# Altium schematic sheet Digital.SchDoc
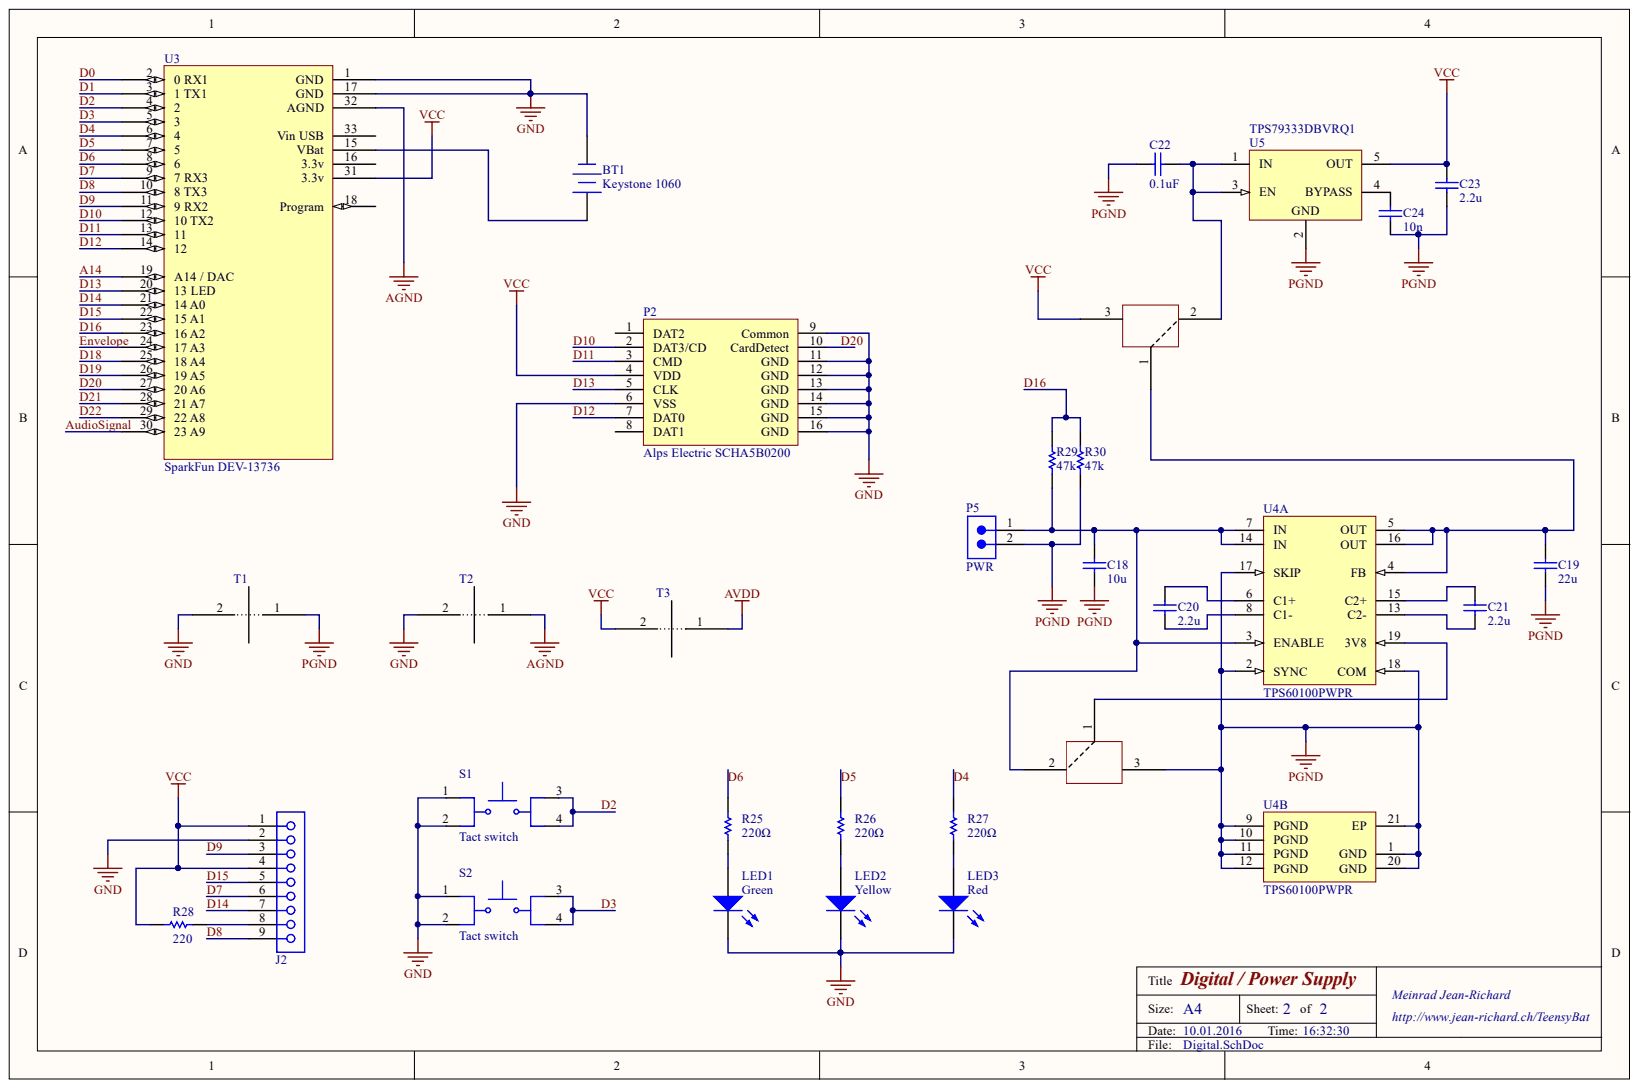
[diagram p0: Digital.SchDoc]

## Parts
BT1  Keystone 1060  [PCB-785wwjdgfrelf4kzosmk-1]
C18  10u  [CAPC3216X178X50ML20T25]
C19  22u  [CAPC3225X280X50ML20T25]
C20  2.2u  [CAPC3216X178X50LL20T25]
C21  2.2u  [CAPC3216X178X50LL20T25]
C22  Capacitance: 0.1uF  [CAPC3216X94X50NL20T25]  (hidden comment: AVX Interconnect / Elco 12065C104KAT2A)
C23  2.2u  [CAPC3216X178X50LL20T25]
C24  10n  [CAPC3216X94X50ML20T25]
J2  Harwin M20-7820942  [PCB-l9sv7n8riqbj6ti1phnw-1]  (value not drawn)
J5  Solder Jumper  [MJR-Jumper]  (value not drawn)
J6  Solder Jumper  [MJR-Jumper]  (value not drawn)
LED1  Color: Green  [Type 5mm]  (hidden comment: 151051VS04000)
LED2  Color: Yellow  [Type 5mm]  (hidden comment: 151051YS04000)
LED3  Color: Red  [Type 5mm]  (hidden comment: 151051RS11000)
P2  Alps Electric SCHA5B0200  Manufacturer Part Number: SCHA5B0200  [SD-MICRO-SCHA5B0200]
P5  PWR  [HDR102]
R25  Resistance: 220Ω  [RESC3216X70X50NL05T20]  (hidden comment: Panasonic ERJ-8ENF2200V)
R26  Resistance: 220Ω  [RESC3216X70X50NL05T20]  (hidden comment: Panasonic ERJ-8ENF2200V)
R27  Resistance: 220Ω  [RESC3216X70X50NL05T20]  (hidden comment: Panasonic ERJ-8ENF2200V)
R28  Panasonic ERJ-8ENF2200V  [RESC3216X70X50NL05T20]  (value not drawn)
R29  47k  [RESC3216X70X50NL05T20]
R30  47k  [RESC3216X70X50NL05T20]
S1  Tact switch  [PCB-1275082-1]
S2  Tact switch  [PCB-1275082-1]
T1  Generic Net Tie  [PCB-NetTie]  (value not drawn)
T2  Generic Net Tie  [PCB-NetTie]  (value not drawn)
T3  Generic Net Tie  [PCB-NetTie]  (value not drawn)
U3  SparkFun DEV-13736  Manufacturer Part Number: DEV-13736  [Teensy-3_2-Pins]
U4  TPS60100PWPR  [TI-PWP20-2680X1950TP_M]  (parts A,B)
U5  TPS79333DBVRQ1  [TI-DBV5_N]

## Nets
AGND: T2.1 U3.32(AGND)
AVDD: T3.1
GND: BT1.2 J2.2 LED1.1 LED2.1 LED3.1 P2.6(VSS) P2.9(Common) P2.11(GND) P2.12(GND) P2.13(GND) P2.14(GND) P2.15(GND) P2.16(GND) S1.1 S1.2 S2.1 S2.2 T1.2 T2.2 U3.1(GND) U3.17(GND)
PGND: C18.1 C19.1 C22.1 C23.1 C24.1 J6.3 P5.2 R30.1 T1.1 U4.1(GND) U4.2(SYNC) U4.9(PGND) U4.10(PGND) U4.11(PGND) U4.12(PGND) U4.17(SKIP) U4.18(COM) U4.20(GND) U4.21(EP) U5.2
VCC: C23.2 J2.1 J2.4 J5.3 P2.4(VDD) R28.1 T3.2 U3.31(3.3v) U5.5(OUT)
A14: U3.19(A14_/_DAC)
AUDIOSIGNAL: U3.30(23_A9)
D0: U3.2(0_RX1)
D1: U3.3(1_TX1)
D2: S1.3 S1.4 U3.4
D3: S2.3 S2.4 U3.5
D4: R27.2 U3.6
D5: R26.2 U3.7
D6: R25.2 U3.8
D7: J2.6 U3.9(7_RX3)
D8: J2.9 U3.10(8_TX3)
D9: J2.3 U3.11(9_RX2)
D10: P2.2(DAT3/CD) U3.12(10_TX2)
D11: P2.3(CMD) U3.13
D12: P2.7(DAT0) U3.14
D13: P2.5(CLK) U3.20(13_LED)
D14: J2.7 U3.21(14_A0)
D15: J2.5 U3.22(15_A1)
D16: R29.2 R30.2 U3.23(16_A2)
D18: U3.25(18_A4)
D19: U3.26(19_A5)
D20: P2.10(CardDetect) U3.27(20_A6)
D21: U3.28(21_A7)
D22: U3.29(22_A8)
ENVELOPE: U3.24(17_A3)
NetBT1_1: BT1.1 U3.15(VBat)
NetC18_2: C18.2 J6.2 P5.1 R29.1 U4.3(ENABLE) U4.7(IN) U4.14(IN)
NetC19_2: C19.2 J5.1 U4.4(FB) U4.5(OUT) U4.16(OUT)
NetC20_1: C20.1 U4.8(C1-)
NetC20_2: C20.2 U4.6(C1+)
NetC21_1: C21.1 U4.13(C2-)
NetC21_2: C21.2 U4.15(C2+)
NetC22_2: C22.2 J5.2 U5.1(IN) U5.3(EN)
NetC24_2: C24.2 U5.4(BYPASS)
NetJ2_8: J2.8 R28.2
NetJ6_1: J6.1 U4.19(3V8)
NetLED1_2: LED1.2 R25.1
NetLED2_2: LED2.2 R26.1
NetLED3_2: LED3.2 R27.1

Not connected: P2 1(DAT2) 8(DAT1); U3 16(3.3v) 18(Program) 33(Vin_USB)

## Library data of the parts
BT1 = CMP-19301741-5: Coin Cell Battery Holders 20MM (2032) SMT CELL HOLDER; Manufacturer Part Number: 1060; Lead-Free Status: Lead Free; Number of Contacts: 2; Color: Black; Component Kind: Standard; Contact Material: Phosphor Bronze; Library Name: CommunityVault; Material: Nylon; Mounting Style: Surface Mount; OctopartID: 24a1eda95ca6fb66; Octopart URL: http://octopart.com/1060-keystone-154977; Packaging: Tray; REACH SVHC Compliance: No SVHC; RoHS: Compliant; Size-Diameter: 0.02 m; Weight: 3400.0 g
C18 = CMP-b9e370e175f96624-1: Cap Ceramic 10uF 6.3V X5R 20% SMD 1206 85°C T/R; Manufacturer Part Number: JMK316BJ106ML-T; Capacitance: 1e-05 F; Case/Package (SI): 3216; Lead-Free Status: Lead Free; Capacitance Tolerance: ±20%; Case/Package: 1206; Component Kind: Standard; Halogen Free Status: Halogen Free; Library Name: CommunityVault; Lifecycle Status: Active; Mounting Style: Surface Mount; OctopartID: b9e370e175f96624; Octopart URL: http://octopart.com/jmk316bj106ml-t-taiyo+yuden-584060; RoHS: Compliant; Size-Height: 0.0016 m; Size-Length: 0.0032 m; Size-Width: 0.0016 m; Voltage Rating (DC): 6.3 V
C19 = CMP-20112617-2: GLOVE, POLYAMIDE, L/FREE, PU, SIZE 6 - More Details; Capacitance: 2.2e-05 F; Capacitance Tolerance: ±10%; Dielectric Characteristic: X5R; Dielectric Material: Ceramic; Lead-Free Status: Lead Free; Voltage Rating (DC): 10.0 V; Case/Package: 1210; Component Kind: Standard; Library Name: CommunityVault; Mounting Style: Surface Mount; Number of Pins: 2; OctopartID: 86e34fe8d81f5924; Octopart Url: https://octopart.com/c1210c226k8pactu-kemet-97178; REACH SVHC Compliance: No SVHC; RoHS: Compliant; Size-Height: 0.00155 m; Size-Length: 0.0032 m; Size-Width: 0.0025 m
C20 C21 C23 = CMP-3902561-2: CAP CER 2.2UF 10V 10% X7R 1206; Capacitance: 2.2e-06 F; Case/Package (SI): 3216; Lead-Free Status: Lead Free; Voltage Rating (DC): 10.0 V; Capacitance Tolerance: ±10%; Case/Package: 1206; Component Kind: Standard; Dielectric Characteristic: X7R; Library Name: CommunityVault; Mounting Style: Surface Mount; Number of Pins: 2; OctopartID: 1db9d6769801c27e; Octopart Url: https://octopart.com/1206zc225kat2a-avx+interconnect+%2F+elco-46506206; REACH SVHC Compliance: No SVHC; RoHS: Compliant; Size-Height: 0.00178 m; Size-Length: 0.0032 m; Size-Width: 0.0016 m
C22 = CMP-126010-2: CAP CER 0.1UF 50V 10% X7R 1206; Case/Package (SI): 3216; Dielectric Material: Ceramic; Insulation Resistance: 1e+11 Ω; Lead-Free Status: Lead Free; Voltage Rating (DC): 50.0 V; Capacitance Tolerance: ±10%; Case/Package: 1206; Component Kind: Standard; Dielectric Characteristic: X7R; Library Name: CommunityVault; Mounting Style: Surface Mount; Number of Pins: 2; OctopartID: 839348c5bc2c5c54; Octopart Url: https://octopart.com/12065c104kat2a-avx+interconnect+%2F+elco-39590617; REACH SVHC Compliance: No SVHC; RoHS: Compliant; Size-Height: 0.00094 m; Size-Length: 0.0032004 m; Size-Thickness: 0.0009398 m; Size-Width: 0.0016002 m
C24 = CMP-15341621-2: Capacitance: 1e-08 F; Capacitance Tolerance: ±10%; Case/Package (SI): 3216; Dielectric Characteristic: X7R; Dielectric Material: Ceramic; Lead-Free Status: Lead Free; Voltage Rating (DC): 50.0 V; Case/Package: 1206; Component Kind: Standard; Library Name: CommunityVault; Mounting Style: Surface Mount; Number of Pins: 2; OctopartID: ddf716a714890230; Octopart Url: https://octopart.com/12065c103kat2a-avx+interconnect+%2F+elco-39513112; REACH SVHC Compliance: No SVHC; RoHS: Compliant; Size-Height: 0.00094 m; Size-Length: 0.0032 m; Size-Width: 0.0016 m
J2 = CMP-d55e1d37e3c80a99-1: 09-Way Sil Vert Socket l/Free; Gender: Female; Component Kind: Standard; Library Name: FavoritesLibrary; Manufacturer Part Number: M20-7820942; Number of Rows: 1; OctopartID: d55e1d37e3c80a99; Octopart URL: http://octopart.com/m20-7820942-harwin-23270384; RoHS: Compliant
J5 J6 = CMP-yzoeddj3i1erkodcjgfc-3: Component Kind: Net Tie; CustomComponentID: yzoeddj3i1erkodcjgfc; Description: Solder Jumper; Library Name: CommunityVault
LED1 = CMP-12518896-2: THT LED round mono-color color lens, WL-TMRW, Bright Green; Forward Voltage: 2.1 V; Luminous Intensity: 0.14 cd; Viewing Angle: 40 °; Component Kind: Standard; Library Name: CommunityVault; OctopartID: 7dcdb5022d9da20a; Octopart Url: https://octopart.com/151051vs04000-w%C3%BCrth+elektronik-29717814; REACH SVHC Compliance: No SVHC; RoHS: Compliant
LED2 = CMP-12518897-2: THT LED round mono-color color lens, WL-TMRW, Yellow; Forward Voltage: 2.0 V; Luminous Intensity: 0.45 cd; Viewing Angle: 40°; Component Kind: Standard; Library Name: CommunityVault; OctopartID: 327efab1622fedb9; Octopart Url: https://octopart.com/151051ys04000-w%C3%BCrth+elektronik-29717815; REACH SVHC Compliance: No SVHC; RoHS: Compliant
LED3 = CMP-12518895-2: THT LED round mono-color color lens, WL-TMRW, Red; Forward Voltage: 2.1 V; Luminous Intensity: 0.015 cd; Viewing Angle: 60°; Component Kind: Standard; Library Name: CommunityVault; OctopartID: 468b8e4416d8994c; Octopart Url: https://octopart.com/151051rs11000-w%C3%BCrth+elektronik-29717813; REACH SVHC Compliance: No SVHC; RoHS: Compliant
P2 = CMP-84d94cf1977e5b1e-2: Conn Micro SD Card RCP 8 POS Solder RA SMD Reel; Component Kind: Standard; Library Name: CommunityVault; OctopartID: 84d94cf1977e5b1e; Octopart Url: http://octopart.com/scha5b0200-alps-19019457; Seller Landing  Url: https://octopart-clicks.com/click/track?country=US&ak=8157f166&sig=0900959&sid=5489&ppid=19019457&vpid=58922165&ct=offers
P5 = CMP-4501201-2: CONN HEADER 2POS .100" SGL GOLD; Gender: Male; Lead-Free Status: Lead Free; Number of Contacts: 2; Pin Pitch: 0.00254 m; Component Kind: Standard; Contact Material: Phosphor Bronze; Contact Plating: Gold; Housing Color: Black; Housing Material: Plastic; Library Name: CommunityVault; Mounting Style: Through Hole; Number of Positions: 2; Number of Rows: 1; OctopartID: 2036e9382d5dfff1; Octopart Url: https://octopart.com/tsw-102-07-s-s-samtec-272397; RoHS: Compliant; Size-Height: 0.00254 m; Size-Length: 0.00508 m; Size-Width: 0.00254 m
R25-R27 = CMP-fe9f37193e9e2158-1: Res Thick Film 1206 220 Ohm 1% 0.25W(1/4W) Â±100ppm/Â°C Molded SMD Automotive T/R; Voltage Rating (DC): 200.0 V; Case/Package: 1206; Component Kind: Standard; Library Name: CommunityVault; Lifecycle Status: Not Listed by Manufacturer; Manufacturer Part Number: ERJ-8ENF2200V; Mounting Style: Surface Mount; Number of Pins: 2; OctopartID: fe9f37193e9e2158; Octopart URL: http://octopart.com/erj-8enf2200v-panasonic-9233767; Packaging: Tape & Reel (TR), Cut Tape (CT); Power Rating: 0.25 W; REACH SVHC Compliance: No SVHC; Resistance Tolerance: ±1%; RoHS: Compliant
R28 = CMP-fe9f37193e9e2158-1: Res Thick Film 1206 220 Ohm 1% 0.25W(1/4W) ±100ppm/°C Molded SMD Automotive T/R; Resistance: 220; Voltage Rating (DC): 200.0 V; Case/Package: 1206; Component Kind: Standard; Library Name: CommunityVault; Lifecycle Status: Not Listed by Manufacturer; Manufacturer Part Number: ERJ-8ENF2200V; Mounting Style: Surface Mount; Number of Pins: 2; OctopartID: fe9f37193e9e2158; Octopart URL: http://octopart.com/erj-8enf2200v-panasonic-9233767; Packaging: Tape & Reel (TR), Cut Tape (CT); Power Rating: 0.25 W; REACH SVHC Compliance: No SVHC; Resistance Tolerance: ±1%; RoHS: Compliant
R29 R30 = CMP-8a94435db0625553-1: Res Thick Film 1206 47K Ohm 1% 0.25W(1/4W) ±100ppm/C Molded SMD Automotive Punched T/R; Manufacturer Part Number: ERJ-8ENF4702V; Lead-Free Status: Lead Free; Resistance: 47000.0 ?; Voltage Rating (DC): 200.0 V; Case/Package: 1206; Component Kind: Standard; Library Name: CommunityVault; Lifecycle Status: Not Listed by Manufacturer; Mounting Style: Surface Mount; Number of Pins: 2; OctopartID: 8a94435db0625553; Octopart URL: http://octopart.com/erj-8enf4702v-panasonic-12787600; Packaging: Tape & Reel (TR), Cut Tape (CT); Power Rating: 0.25 W; REACH SVHC Compliance: No SVHC; Resistance Tolerance: ±1%; RoHS: Compliant
S1 S2 = CMP-1275082-6: Tactile Switches 6mm square tact; Contacts Type: SPST; Component Kind: Standard; Library Name: CommunityVault; Mounting Style: PCB; OctopartID: 0d02c405c584d2c5; Octopart Url: https://octopart.com/mjtp1230a-apem-912864; RoHS: Compliant
T1-T3 = CMP-g4tdb29lxx9p76v106u3-10: Component Kind: Net Tie; CustomComponentID: g4tdb29lxx9p76v106u3; Description: Generic Net Tie; Library Name: CommunityVault
U3 = CMP-cc4306b2fccc1384-5: Dev Teensy 3.2 / 3.1 13736; Component Kind: Standard; Library Name: CommunityVault; OctopartID: cc4306b2fccc1384; Octopart URL: http://octopart.com/dev-13736-sparkfun-66786793
U4 = CMP-13602593-3: 3.3 V, Boost Charge Pump, 200 mA, 1.8 to 3.6 V Input, -40 to 85 degC, 20-pin SOP (PWP20), Green (RoHS & no Sb/Br); Lead-Free Status: Lead Free; Number of Regulated Outputs: 1; Output Current: 0.2 A; Output Voltage: 3.3 V; Quiescent Current: 5e-05 A; Component Kind: Standard; Library Name: CommunityVault; Lifecycle Status: Active; Number of Pins: 20; OctopartID: 9c0d556b264f43ca; Octopart Url: https://octopart.com/tps60100pwpr-texas+instruments-7118628; RoHS: Compliant; Topology: Boost
U5 = CMP-1494246-2: Single Output Fast RF LDO, 200 mA, Fixed 3.3 V Output, 2.7 to 5.5 V Input, 5-pin SOT-23 (DBV), -40 to 125 degC, Green (RoHS & no Sb/Br); Dropout Voltage: 0.112 V; Lead-Free Status: Lead Free; Number of Outputs: 1; Output Capacitor Type: Ceramic; Output Current: 0.2 A; Output Voltage: 3.3 V; Quiescent Current: 0.00017 A; Case/Package: SOT-23; Component Kind: Standard; Library Name: CommunityVault; Lifecycle Status: Active; Mounting Style: Surface Mount; Number of Pins: 5; Number of Regulated Outputs: 1; OctopartID: 81224820a0285ee5; Octopart Url: https://octopart.com/tps79333dbvrq1-texas+instruments-525420; Packaging: Cut Tape (CT); REACH SVHC Compliance: No SVHC; RoHS: Compliant
